# Altium schematic sheet ESP32_S3.SchDoc
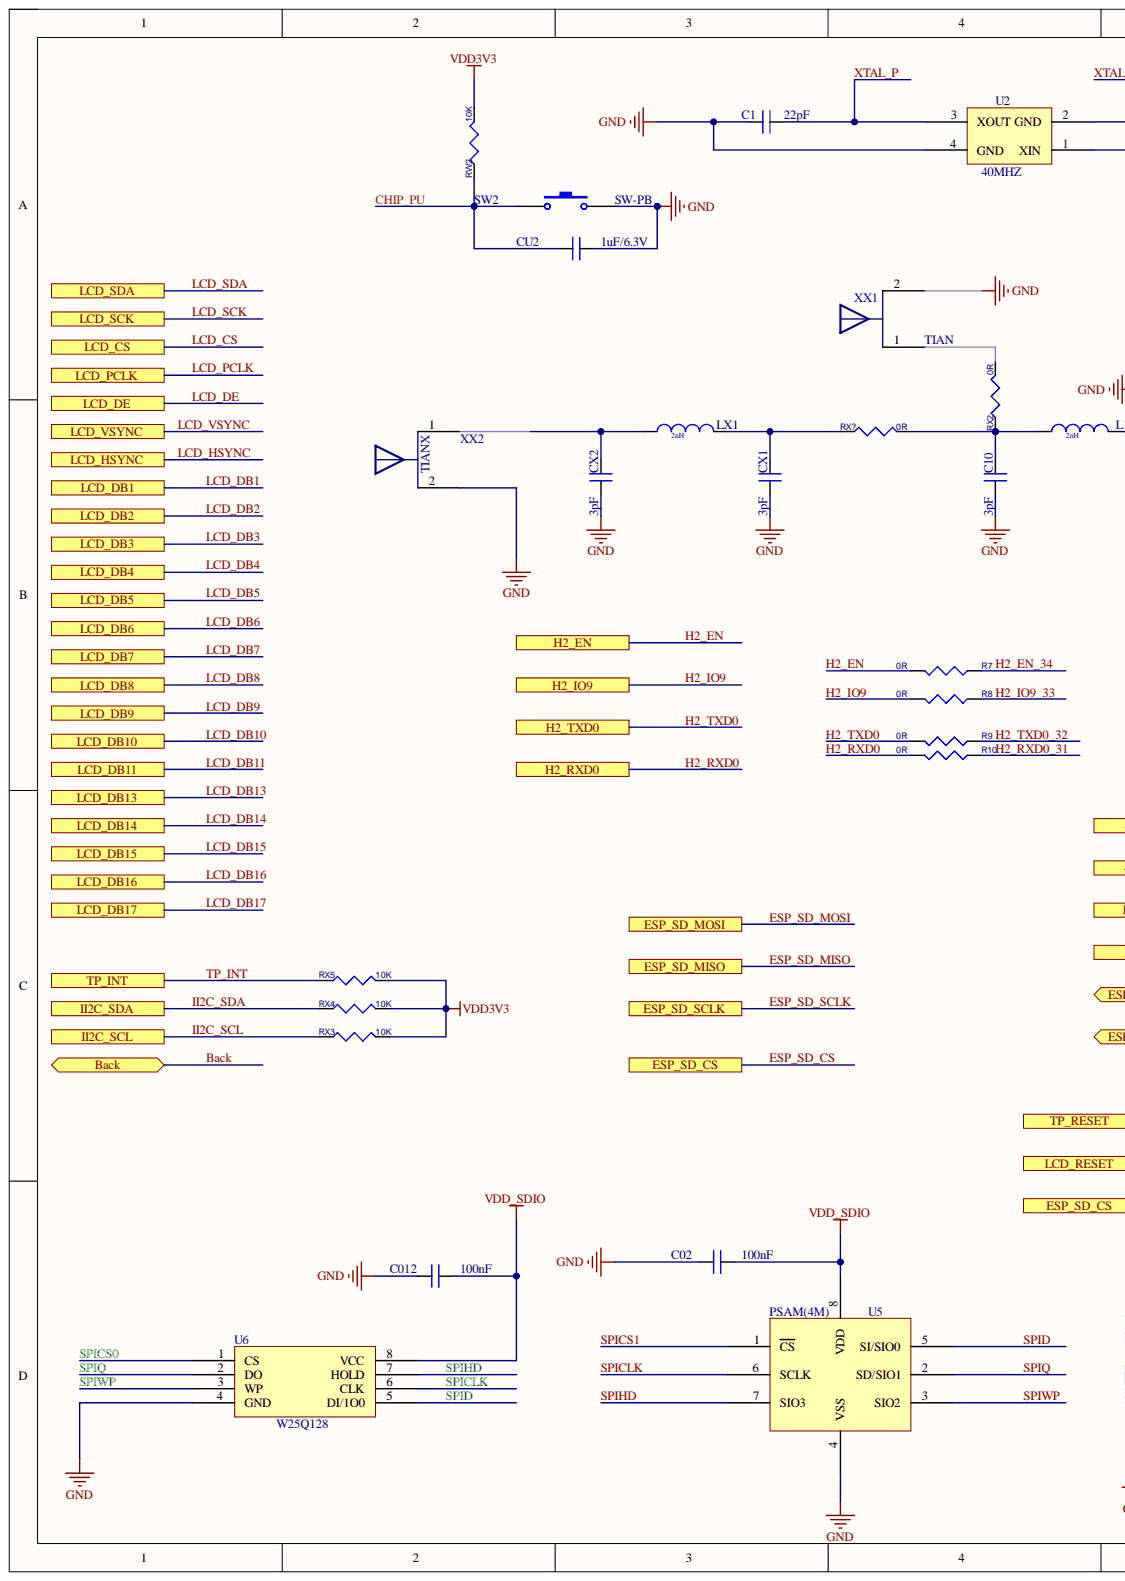
[diagram p0: ESP32_S3.SchDoc, left]
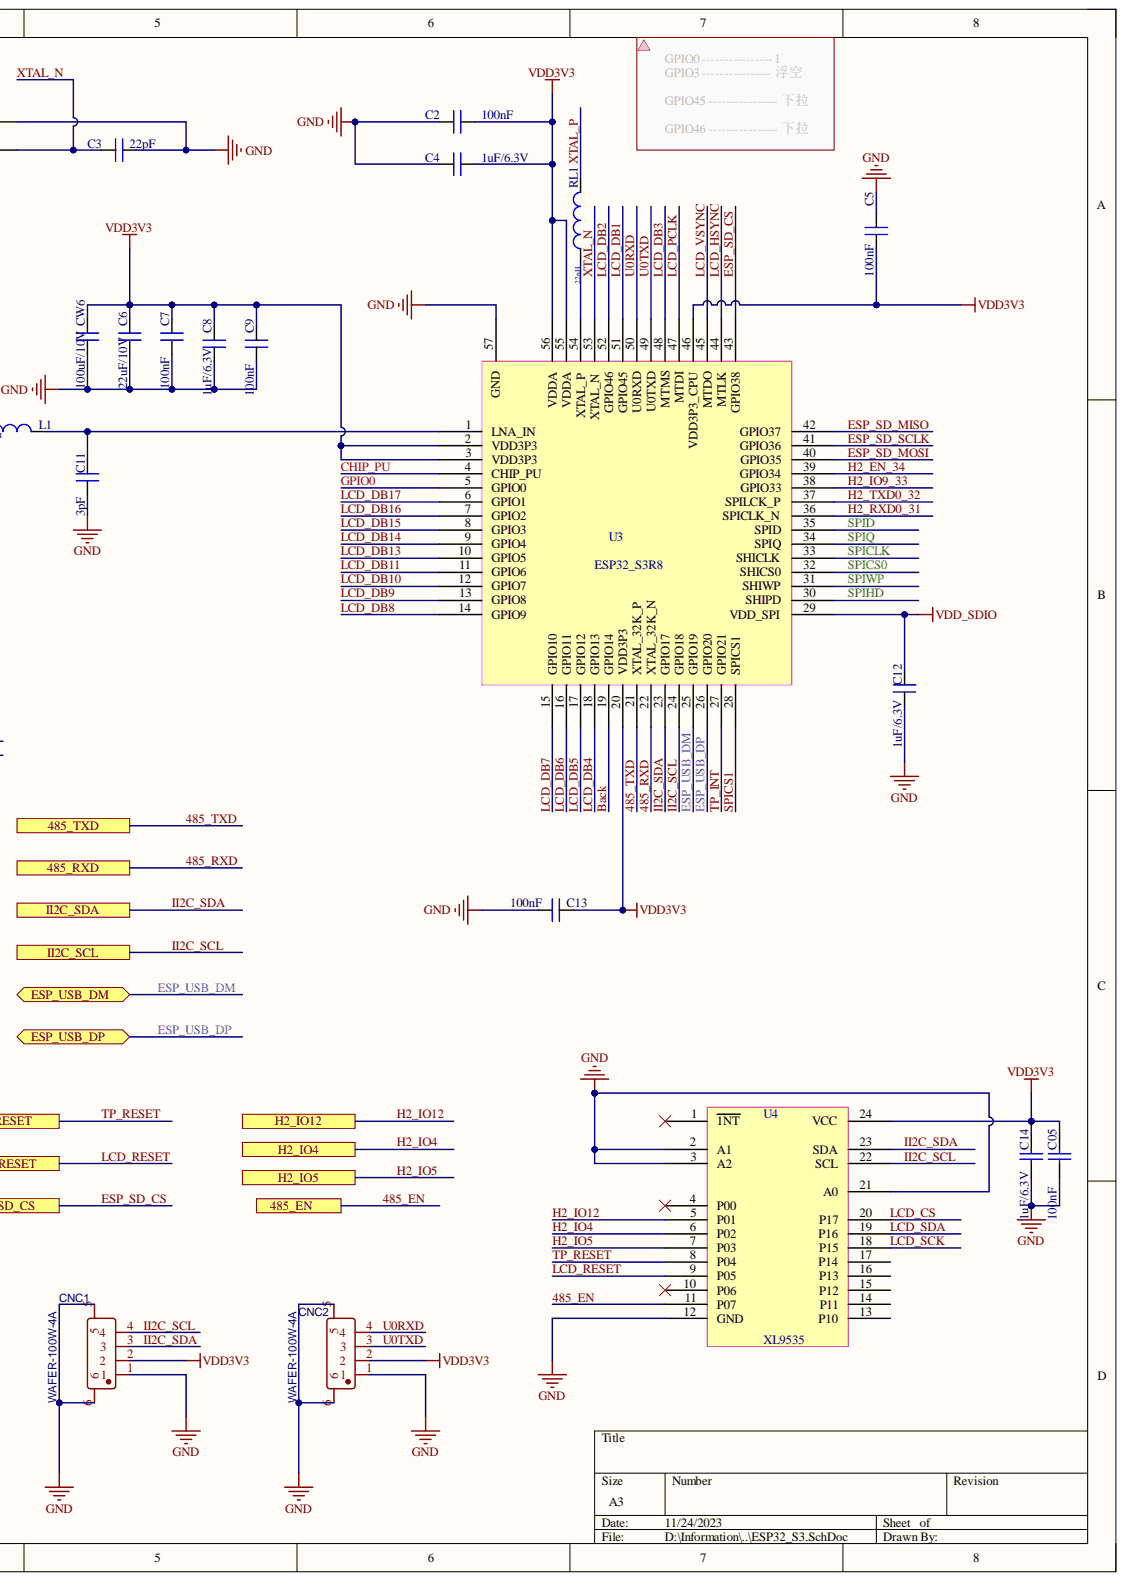
[diagram p1: ESP32_S3.SchDoc, right]

## Parts
C1  22pF  [LC-0402_C]
C2  100nF  [LC-0402_C]
C02  100nF  [LC-0402_C]
C3  22pF  [LC-0402_C]
C4  1uF/6.3V  [LC-0402_C]
C5  100nF  [LC-0402_C]
C05  100nF  [LC-0402_C]
C6  22uF/10V  [LC-0805_C]
C7  100nF  [LC-0603_C]
C8  1uF/6.3V  [LC-0402_C]
C9  100nF  [LC-0402_C]
C10  3pF  [LC-0402_C]
C11  3pF  [LC-0402_C]
C12  1uF/6.3V  [LC-0402_C]
C012  100nF  [LC-0402_C]
C13  100nF  [LC-0402_C]
C14  1uF/6.3V  [LC-0402_C]
CNC1  WAFER-100W-4A  [CONN-SMD_4P-P1.00_XUNPU_WAFER-100W-4P]
CNC2  WAFER-100W-4A  [CONN-SMD_4P-P1.00_XUNPU_WAFER-100W-4P]
CU2  1uF/6.3V  [LC-0402_C]
CW6  100uF/10V  [LC-0805_C]
CX1  3pF  [LC-0402_C]
CX2  3pF  [LC-0402_C]
L1  2nH  [LC-0402_L]
LX1  2nH  [LC-0402_L]
R7  0R  [LC-0402_R]
R8  0R  [LC-0402_R]
R9  0R  [LC-0402_R]
R10  0R  [LC-0402_R]
RL1  22nH  [LC-0402_L]
RW2  10K  [LC-0402_R]
RX2  0R  [LC-0402_R]
RX3  10K  [LC-0402_R]
RX4  10K  [LC-0402_R]
RX5  10K  [LC-0402_R]
RX?  0R  [LC-0402_R]
SW2  SW-PB  [KEY--4P]
U2  40MHZ  [XTAL3225]
U3  ESP32_S3R8  [QFN-56]
U4  XL9535  [TSSOP-24_L7.8-W4.4-P0.65-LS6.4-BL]
U5  PSAM(4M)  [SOIC-8P]
U6  W25Q128  [SOP-8_L5.3-W5.3-P1.27-LS8.0-BL]
XX1  TIAN  [ANT_JACK]
XX2  TIANX  [ANT-2.4G-3D]

## Ports
485_EN, 485_RXD, 485_TXD, Back, ESP_SD_CS, ESP_SD_MISO, ESP_SD_MOSI, ESP_SD_SCLK, ESP_USB_DM, ESP_USB_DP, H2_EN, H2_IO4, H2_IO5, H2_IO9, H2_IO12, H2_RXD0, H2_TXD0, II2C_SCL, II2C_SDA, LCD_CS, LCD_DB1, LCD_DB2, LCD_DB3, LCD_DB4, LCD_DB5, LCD_DB6, LCD_DB7, LCD_DB8, LCD_DB9, LCD_DB10, LCD_DB11, LCD_DB13, LCD_DB14, LCD_DB15, LCD_DB16, LCD_DB17, LCD_DE, LCD_HSYNC, LCD_PCLK, LCD_RESET, LCD_SCK, LCD_SDA, LCD_VSYNC, TP_INT, TP_RESET

## Nets
GND: C1.1 C02.1 C2.2 C3.2 C4.2 C5.2 C05.2 C6.2 C7.2 C8.2 C9.2 C10.2 C11.2 C012.1 C12.1 C13.1 C14.2 CNC1.1 CNC1.5 CNC1.6 CNC2.1 CNC2.5 CNC2.6 CU2.1 CW6.2 CX1.2 CX2.2 SW2.2 U2.2(GND) U2.4(GND) U3.57(GND) U4.2(A1) U4.3(A2) U4.12(GND) U4.21(A0) U5.4(VSS) U6.4(GND) XX1.2 XX2.2
VDD3V3: C2.1 C4.1 C5.1 C05.1 C6.1 C7.1 C8.1 C9.1 C13.2 C14.1 CNC1.2 CNC2.2 CW6.1 RW2.2 RX3.2 RX4.2 RX5.2 U3.2(VDD3P3) U3.3(VDD3P3) U3.20(VDD3P3) U3.46(VDD3P3_CPU) U3.55(VDDA) U3.56(VDDA) U4.24(VCC)
VDD_SDIO: C02.2 C012.2 C12.2 U3.29(VDD_SPI) U5.8(VDD) U6.8(VCC)
485_EN: U4.11(P07) ; port 485_EN
485_RXD: U3.22(XTAL_32K_N) ; port 485_RXD
485_TXD: U3.21(XTAL_32K_P) ; port 485_TXD
Back: U3.19(GPIO14) ; port Back
CHIP_PU: CU2.2 RW2.1 SW2.1 U3.4(CHIP_PU)
ESP_SD_CS: U3.43(GPIO38) ; port ESP_SD_CS
ESP_SD_MISO: U3.42(GPIO37) ; port ESP_SD_MISO
ESP_SD_MOSI: U3.40(GPIO35) ; port ESP_SD_MOSI
ESP_SD_SCLK: U3.41(GPIO36) ; port ESP_SD_SCLK
ESP_USB_DM: U3.25(GPIO19) ; port ESP_USB_DM
ESP_USB_DP: U3.26(GPIO20) ; port ESP_USB_DP
GPIO0: U3.5(GPIO0)
H2_EN: R7.2 ; port H2_EN
H2_EN_34: R7.1 U3.39(GPIO34)
H2_IO4: U4.6(P02) ; port H2_IO4
H2_IO5: U4.7(P03) ; port H2_IO5
H2_IO9: R8.2 ; port H2_IO9
H2_IO9_33: R8.1 U3.38(GPIO33)
H2_IO12: U4.5(P01) ; port H2_IO12
H2_RXD0: R10.2 ; port H2_RXD0
H2_RXD0_31: R10.1 U3.36(SPICLK_N)
H2_TXD0: R9.2 ; port H2_TXD0
H2_TXD0_32: R9.1 U3.37(SPILCK_P)
II2C_SCL: CNC1.4 RX3.1 U3.24(GPIO18) U4.22(SCL) ; port II2C_SCL
II2C_SDA: CNC1.3 RX4.1 U3.23(GPIO17) U4.23(SDA) ; port II2C_SDA
LCD_CS: U4.20(P17) ; port LCD_CS
LCD_DB1: U3.51(GPIO45) ; port LCD_DB1
LCD_DB2: U3.52(GPIO46) ; port LCD_DB2
LCD_DB3: U3.48(MTMS) ; port LCD_DB3
LCD_DB4: U3.18(GPIO13) ; port LCD_DB4
LCD_DB5: U3.17(GPIO12) ; port LCD_DB5
LCD_DB6: U3.16(GPIO11) ; port LCD_DB6
LCD_DB7: U3.15(GPIO10) ; port LCD_DB7
LCD_DB8: U3.14(GPIO9) ; port LCD_DB8
LCD_DB9: U3.13(GPIO8) ; port LCD_DB9
LCD_DB10: U3.12(GPIO7) ; port LCD_DB10
LCD_DB11: U3.11(GPIO6) ; port LCD_DB11
LCD_DB13: U3.10(GPIO5) ; port LCD_DB13
LCD_DB14: U3.9(GPIO4) ; port LCD_DB14
LCD_DB15: U3.8(GPIO3) ; port LCD_DB15
LCD_DB16: U3.7(GPIO2) ; port LCD_DB16
LCD_DB17: U3.6(GPIO1) ; port LCD_DB17
LCD_HSYNC: U3.44(MTLK) ; port LCD_HSYNC
LCD_PCLK: U3.47(MTDI) ; port LCD_PCLK
LCD_RESET: U4.9(P05) ; port LCD_RESET
LCD_SCK: U4.18(P15) ; port LCD_SCK
LCD_SDA: U4.19(P16) ; port LCD_SDA
LCD_VSYNC: U3.45(MTDO) ; port LCD_VSYNC
SPICLK: U3.33(SHICLK) U5.6(SCLK) U6.6(CLK)
SPICS0: U3.32(SHICS0) U6.1(CS)
SPICS1: U3.28(SPICS1) U5.1(CS)
SPID: U3.35(SPID) U5.5(SI/SIO0) U6.5(DI/1O0)
SPIHD: U3.30(SHIPD) U5.7(SIO3) U6.7(HOLD)
SPIQ: U3.34(SPIQ) U5.2(SD/SIO1) U6.2(DO)
SPIWP: U3.31(SHIWP) U5.3(SIO2) U6.3(WP)
TP_INT: RX5.1 U3.27(GPIO21) ; port TP_INT
TP_RESET: U4.8(P04) ; port TP_RESET
U0RXD: CNC2.4 U3.50(U0RXD)
U0TXD: CNC2.3 U3.49(U0TXD)
XTAL_N: C3.1 U2.1(XIN) U3.53(XTAL_N)
XTAL_P: C1.2 RL1.2 U2.3(XOUT)
NetC10_1: C10.1 L1.1 RX2.1 RX?.2
NetC11_1: C11.1 L1.2 U3.1(LNA_IN)
NetCX1_1: CX1.1 LX1.2 RX?.1
NetCX2_1: CX2.1 LX1.1 XX2.1
NetRL1_1: RL1.1 U3.54(XTAL_P)
NetRX2_2: RX2.2 XX1.1
LCD_DE: ; port LCD_DE

Not connected: U4 1(1NT) 4(P00) 10(P06) 13(P10) 14(P11) 15(P12) 16(P13) 17(P14)

## Library data of the parts
C1 C2 C02-C12 C012 C13 CU2 CW6 CX1 CX2 = C: Component Kind: Standard; Library Name: 光学蓝牙.SCHLIB
C05 C14 = Cap: Capacitor; Value: 100pF; Component Kind: Standard; LatestRevisionDate: 17-Jul-2002; LatestRevisionNote: Re-released for DXP Platform.; Library Name: Miscellaneous Devices.IntLib; PackageReference: RAD-0.3; Published: 8-Jun-2000; Publisher: Altium Limited
CNC1 CNC2 = WAFER-100W-4A: Contributor: LCSC; BOM_JLCPCB Part Class: Extended Part; BOM_Manufacturer Part: WAFER-100W-4A; BOM_Supplier: LCSC; BOM_Supplier Part: C2874471; Component Kind: Standard; spicePre: C; spiceSymbolName: WAFER-100W-4A
L1 LX1 = (no symbol name): Power Inductor, 4.7uH, 0.7A, 260mR DCR; Manufacturer: Taiyo Yuden; Manufacturer Part Number: CBC2518T4R7M; Part Creator: JG; XMOS Part Number: E-09-0011; Approved: NO; Approx Volume Cost ($): 0.07; DEVICE: L; FORWARD_PCB: 1; LEVEL: STD; Max DC Resistance: 0.26R; Rated Current (Saturation): 0.68A; Rated Current (Temp Rise): 0.92A; Tolerance (%): 20; VALUE: 2.0nH; Component Kind: Standard; Datasheet: http://www.yuden.co.jp/us/product/pdf/ecb2_e.pdf; Digikey Link: http://search.digikey.com/scripts/DkSearch/dksus.dll?lang=en&site=US&keywords=CBC2518T4R7M; Library Name: MySchlib.SchLib; Max Height (mm): 2
R7-R10 RW2 RX2-RX5 RX? = R: Component Kind: Standard; Library Name: 光学蓝牙.SCHLIB
RL1 = INDUCTOR: Power Inductor, 4.7uH, 0.7A, 260mR DCR; Manufacturer: Taiyo Yuden; Manufacturer Part Number: CBC2518T4R7M; Part Creator: JG; XMOS Part Number: E-09-0011; Approved: NO; Approx Volume Cost ($): 0.07; DEVICE: L; FORWARD_PCB: 1; LEVEL: STD; Max DC Resistance: 0.26R; Rated Current (Saturation): 0.68A; Rated Current (Temp Rise): 0.92A; Tolerance (%): 20; VALUE: 2.0nH; Component Kind: Standard; Datasheet: http://www.yuden.co.jp/us/product/pdf/ecb2_e.pdf; Digikey Link: http://search.digikey.com/scripts/DkSearch/dksus.dll?lang=en&site=US&keywords=CBC2518T4R7M; Library Name: MySchlib.SchLib; Max Height (mm): 2
SW2 = SW-PB: Switch; Component Kind: Standard; LatestRevisionDate: 17-Jul-2002; LatestRevisionNote: Re-released for DXP Platform.; Library Name: Miscellaneous Devices.IntLib; PackageReference: SPST-2; Published: 24-Mar-1999; Publisher: Altium Limited
U2 = CRY-D3225: Component Kind: Standard; Library Name: 885阿里云PCB_Project1.SCHLIB
U3 = ESP32_S3: Component Kind: Standard; Library Name: 885阿里云PCB_Project1.SCHLIB
U5 = PSAM: Component Kind: Standard; Library Name: K210--Crazy.SCHLIB
U6 = GD25LQ128DSIGR: Component Kind: Standard; Library Name: 885阿里云PCB_Project1.SCHLIB
XX1 = TIANX: Component Kind: Standard; Library Name: 885阿里云PCB_Project1.SCHLIB
U4 = XL9535: Component Kind: Standard; Library Name: 885阿里云PCB_Project1.SCHLIB
XX2 = TIANX: Component Kind: Standard; Library Name: 885阿里云PCB_Project1.SCHLIB
